# Altium schematic sheet Sensor-Sheet1.SchDoc(Sensor-Sheet1)
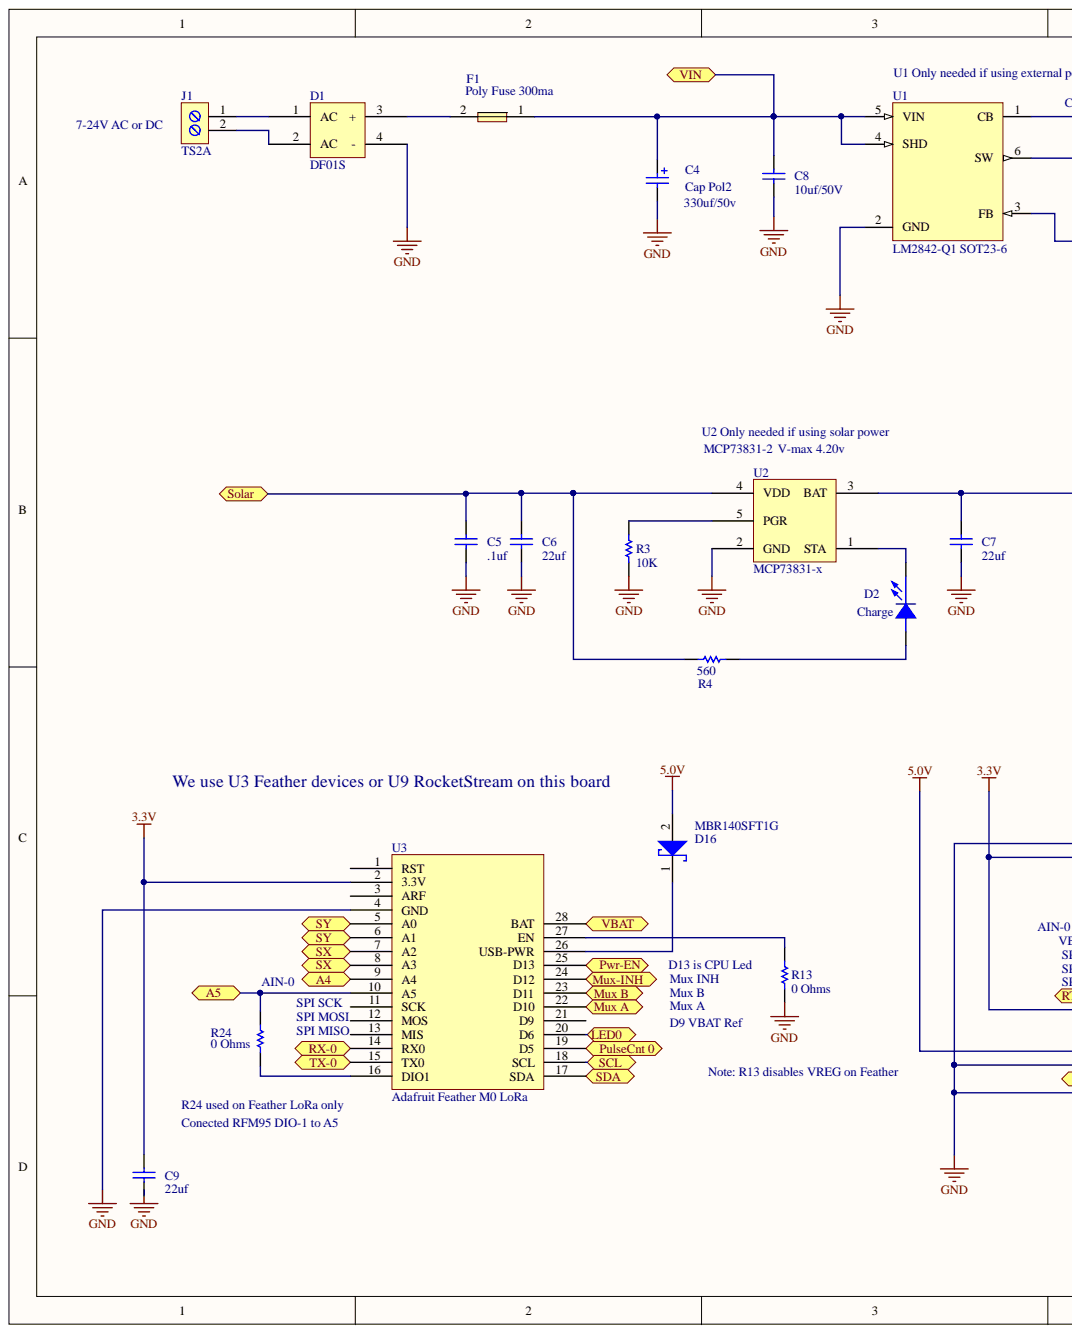
[diagram p0: Sensor-Sheet1.SchDoc, left]
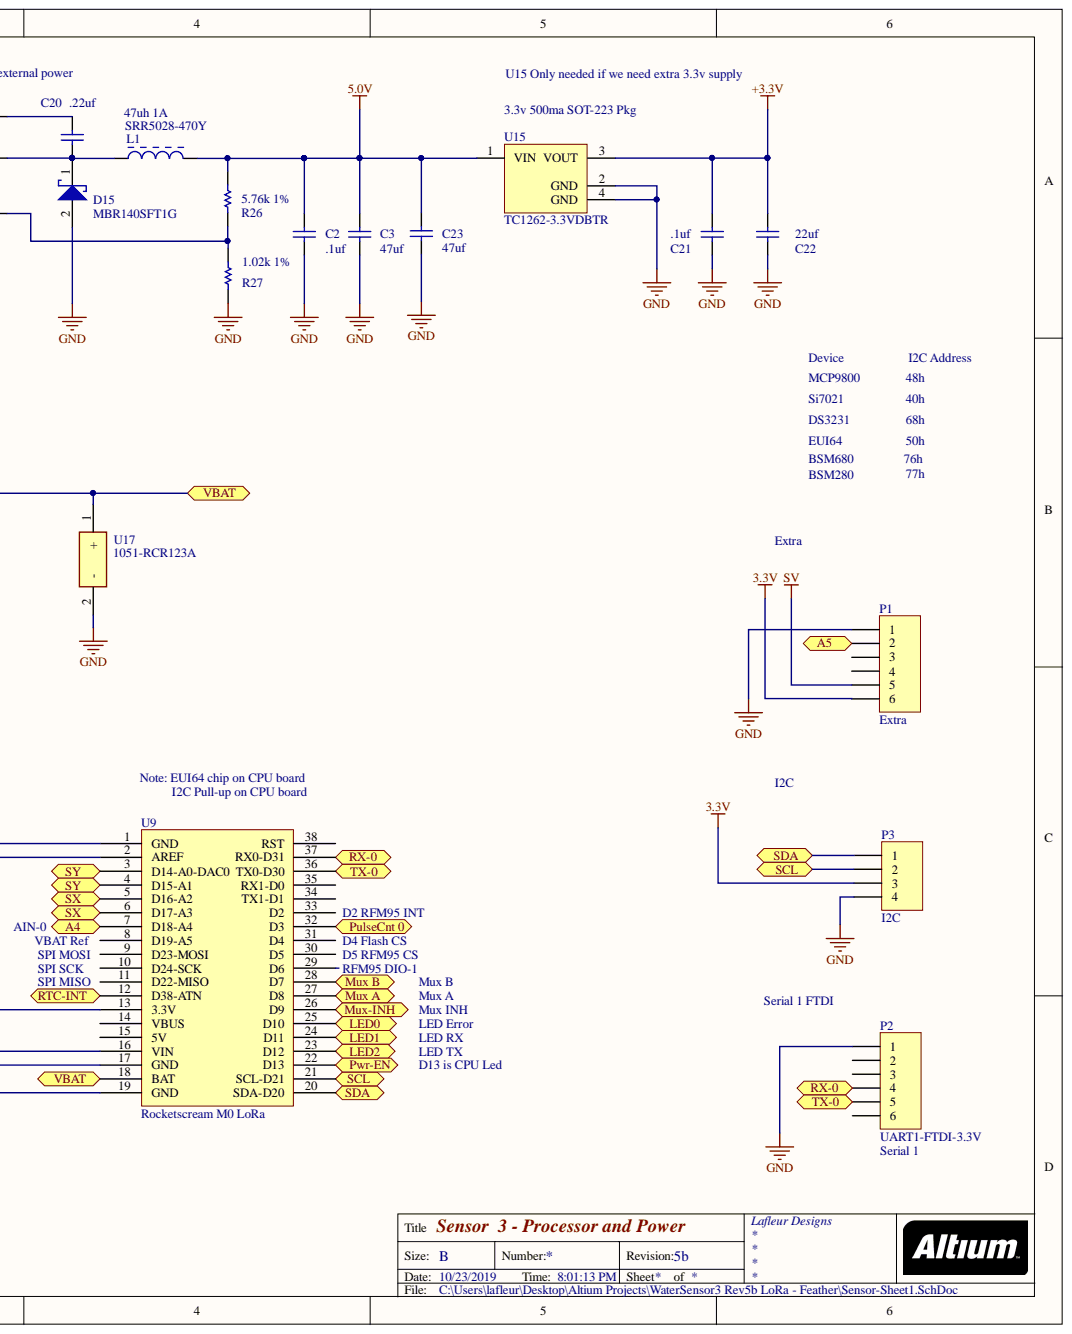
[diagram p1: Sensor-Sheet1.SchDoc, right]

## Parts
C2  .1uf  [6-0805]
C3  47uf  [C1210]
C4  Cap Pol2  value 330uf/50v  [Capacitor 12x5x18]
C5  .1uf  [0805]
C6  22uf  [C1206]
C7  22uf  [C1206]
C8  10uf/50V  [C1206]
C9  22uf  [C1206]
C20  .22uf  [6-0805]
C21  .1uf  [6-0805]
C22  22uf  [0805]
C23  47uf  [C1210]
D1  DF01S  [SOP4-Bridge]
D2  Charge  [LED 0805]
D15  MBR140SFT1G  [SOD123FL-2]
D16  MBR140SFT1G  [SOD123FL-2]
F1  Poly Fuse 300ma  [PTC 2016L]
J1  TS2A  [TS3.81X2]
L1  SRR5028-470Y  [BOUR-SRR5028_V -Mod]
P1  Extra  [HDR1X6]
P2  UART1-FTDI-3.3V  [HDR1X6]
P3  I2C  [HDR1X4]
R3  10K  [6-0805]
R4  560  [6-0805]
R13  0 Ohms  [6-0805]
R24  0 Ohms  [6-0805]
R26  5.76k 1%  [6-0805]
R27  1.02k 1%  [6-0805]
U1  LM2842-Q1 SOT23-6  [sot23-6]
U2  MCP73831-x  [SOT-23-OT5_L]
U3  Adafruit Feather M0 LoRa  [Feather-DIP28-800mil - duplicate]
U9  Rocketscream M0 LoRa  [Rocket-DIP38-900mil]
U15  TC1262-3.3VDBTR  [SOT-223-DB3_N]
U17  1051-RCR123A  [1051]

## Ports
A4, A5, LED0, LED1, LED2, Mux A, Mux B, Mux-INH, PulseCnt 0, Pwr-EN, RTC-INT, RX-0, SCL, SDA, Solar, SX, SY, TX-0, VBAT, VIN

## Nets
5.0V: C2.1 C3.1 C23.1 D16.2 L1.2 R26.1 U9.16(VIN) U15.1(VIN)
+3.3V: C9.1 C21.1 C22.1 P1.6 P3.3 U3.2(3.3V) U9.2(AREF) U9.13(3.3V) U15.3(VOUT)
GND: C2.2 C3.2 C4.1 C5.2 C6.2 C7.2 C8.2 C9.2 C21.2 C22.2 C23.2 D1.4(-) D15.2 P1.1 P2.1 P3.4 R3.1 R13.1 R27.2 U1.2(GND) U2.2(GND) U3.4(GND) U9.1(GND) U9.17(GND) U9.19(GND) U15.2(GND) U15.4(GND) U17.2
SV: P1.5
A4: U3.9(A4) U9.7(D18-A4) ; port A4
A5: P1.2 R24.1 U3.10(A5) ; port A5
LED0: U3.20(D6) U9.25(D10) ; port LED0
LED1: U9.24(D11) ; port LED1
LED2: U9.23(D12) ; port LED2
Mux A: U3.22(D10) U9.27(D8) ; port Mux A
Mux B: U3.23(D11) U9.28(D7) ; port Mux B
Mux-INH: U3.24(D12) U9.26(D9) ; port Mux-INH
PulseCnt 0: U3.19(D5) U9.32(D3) ; port PulseCnt 0
Pwr-EN: U3.25(D13) U9.22(D13) ; port Pwr-EN
RTC-INT: U9.12(D38-ATN) ; port RTC-INT
RX-0: P2.4 U3.14(RX0) U9.37(RX0-D31) ; port RX-0
SCL: P3.2 U3.18(SCL) U9.21(SCL-D21) ; port SCL
SDA: P3.1 U3.17(SDA) U9.20(SDA-D20) ; port SDA
Solar: C5.1 C6.1 R4.2 U2.4(VDD) ; port Solar
SX: U3.7(A2) U3.8(A3) U9.5(D16-A2) U9.6(D17-A3) ; port SX
SY: U3.5(A0) U3.6(A1) U9.3(D14-A0-DAC0) U9.4(D15-A1) ; port SY
TX-0: P2.5 U3.15(TX0) U9.36(TX0-D30) ; port TX-0
VBAT: C7.1 U2.3(BAT) U3.28(BAT) U9.18(BAT) U17.1 ; port VBAT
VIN: C4.2 C8.1 F1.1 U1.4(SHD) U1.5(VIN) ; port VIN
NetC20_1: C20.1 U1.1(CB)
NetC20_2: C20.2 D15.1 L1.1 U1.6(SW)
NetD1_1: D1.1(AC) J1.1
NetD1_2: D1.2(AC) J1.2
NetD1_3: D1.3(+) F1.2
NetD2_1: D2.1 R4.1
NetD2_2: D2.2 U2.1(STA)
NetD16_1: D16.1 U3.26(USB-PWR)
NetP1_3: P1.3
NetP1_4: P1.4
NetP2_2: P2.2
NetP2_3: P2.3
NetP2_6: P2.6
NetR3_2: R3.2 U2.5(PGR)
NetR13_2: R13.2 U3.27(EN)
NetR24_2: R24.2 U3.16(DIO1)
NetR26_2: R26.2 R27.1 U1.3(FB)
NetU3_1: U3.1(RST)
NetU3_3: U3.3(ARF)
NetU3_11: U3.11(SCK)
NetU3_12: U3.12(MOS)
NetU3_13: U3.13(MIS)
NetU3_21: U3.21(D9)
NetU9_8: U9.8(D19-A5)
NetU9_9: U9.9(D23-MOSI)
NetU9_10: U9.10(D24-SCK)
NetU9_11: U9.11(D22-MISO)
NetU9_14: U9.14(VBUS)
NetU9_15: U9.15(5V)
NetU9_29: U9.29(D6)
NetU9_30: U9.30(D5)
NetU9_31: U9.31(D4)
NetU9_33: U9.33(D2)
NetU9_34: U9.34(TX1-D1)
NetU9_35: U9.35(RX1-D0)
NetU9_38: U9.38(RST)

## Library data of the parts
C2 C3 C5-C9 C20 C23 = CAP_1: Capacitor; Fitted: True; Library Name: N2K Project.SCHLIB; Component Kind: Standard
C4 = Cap Pol2: Polarized Capacitor (Axial); Simulation: CAP; Published: 8-Jun-2000; PackageReference: POLAR0.8; PackageDescription: Polarized Capacitor; 2 Leads; Library Name: Miscellaneous Devices.IntLib; LatestRevisionNote: Re-released for DXP Platform.; LatestRevisionDate: 17-Jul-2002; Component Kind: Standard; Publisher: Altium Limited
C21 C22 = CAP_2: Capacitor; Fitted: True; Component Kind: Standard; Library Name: Winmor-Demo-R2.IntLib
D1 = Bridge DF01S: Library Name: WaterSensor.SCHLIB; Component Kind: Standard
D2 = LTST150: Vendor Part Number: LTST-C150GKRT; Part Description: SMT LED Green; Vendor: LITEON; Flex_PN: D20000; DEVICE: C150; Component Kind: Standard; Library Name: Winmor-Demo-R1.IntLib
D15 D16 = Diode Schottky: Schottky Diode; Published: 5-Jan-2004; DatasheetDocument: RevB, 21-Aug-2003; Component Kind: Standard; Publisher: Altium Limited; Library Name: Winmor-Demo-R2.IntLib
F1 = Poly Fuse: Library Name: N2K Project.SCHLIB; Description: Poly Fuse; Component Kind: Standard
J1 = TS2A: Screw Terminal, 3.81mm (.15in) 2X; Library Name: WaterSensor_1.SCHLIB; Component Kind: Standard
L1 = SRR5028-470Y: SDR0403 Series Power Inductor, 18 uF +/- 20 %, 0.34 Ohm, -40 to 125 degC, 2-Pin SMD, RoHS, Tape and Reel; PartNumber: SDR0403-180ML; Manufacturer: Bourns; Tolerance (%): +/- 20; Test Frequency (MHz): 2.52; SRF (MHz): 23 Min.; RDC (Ohm): 0.34 Max.; Q: 25; I sat (A): 1.3 Typ.; I rms (A): 0.84 Max.; Inductance (uH): 18; Temperature Range: -40 to 125 degC; RoHS: TRUE; Packing: Tape and Reel; PackageReference: SDR0403-2; PackageDescription: 3-Pin SMD, Dia 4.5 mm, Height 3.5 mm; Mounting Technology: Surface Mount; Manufacturer Part Number: SDR0403-180ML; Library Name: WaterSensor V3-5_1.SCHLIB; DatasheetVersion: Feb-12; ComponentLink2URL: http://www.bourns.com/data/global/pdfs/sdr0403.pdf; ComponentLink2Description: Datasheet; ComponentLink1URL: http://www.bourns.com/; ComponentLink1Description: Manufacturer URL; Component Kind: Standard
P1 P2 = Header 6: Header, 6-Pin; LatestRevisionNote: Re-released for DXP Platform.; LatestRevisionDate: 17-Jul-2002; Component Kind: Standard; Publisher: Altium Limited; Library Name: BG-Barometric-Sensor Rev5 802.SCHLIB
P3 = Header 4: Header, 4-Pin; Library Name: Miscellaneous Connectors.IntLib; LatestRevisionNote: Re-released for DXP Platform.; LatestRevisionDate: 17-Jul-2002; Component Kind: Standard; Publisher: Altium Limited
R3 R4 R13 R24 R26 R27 = ERJ8EN: Precision Thick Film Chip Resistor, 10 Ohm to 2.2M Ohm Range, 1% Tolerance, 1206 Size, 0.125 W; Simulation: RESISTOR; Published: 17-Dec-2003; PackageReference: 8-1206; PackageDocument: Mar-2003; LatestRevisionNote: Silkscreen size reduced.; LatestRevisionDate: 19-Mar-2004; ComponentLink2URL: http://www.panasonic.com/industrial/components/pdf/AOA0000CE2.pdf; ComponentLink2Description: Datasheet; ComponentLink1URL: http://www.panasonic.com/industrial/components/resistive_products.htm; ComponentLink1Description: Manufacturer Link; Component Kind: Standard; Code_IPC: 3216 [1206]; Publisher: Altium Limited
  R3 R4 R13 R24: Library Name: N2K Project.SCHLIB
  R26 R27: Library Name: Panasonic Resistor.IntLib
U1 = LM2842: .6A SIMPLE SWITCHER® Step-Down Voltage Regulator SOT23-6; Manufacturer: National Semiconductor; PowerWise: N; PackageTopMarking: NSXYTT*L22670*5.0; RoHS: Y; RevisionNote: DatasheetVersion Updated. Component URLs added; PinCount: 8; PackageVersion: revB, Dec-2007; PackageReference: MRA08B; PackageDescription: Molded, PSOP .193 x .154 x .058in, 8 Lead, .050in Pitch, Large DAP; Library Name: WaterSensor 2_7.SCHLIB; DatasheetVersion: Jan-2011; CompType: Switcher; ComponentLink3URL: http://www.national.com/packaging/folders/mra08b.html; ComponentLink3Description: MRA08B Package Page; ComponentLink2URL: http://www.national.com/pf/LM/LM22670.html; ComponentLink2Description: LM22670 Web Page; ComponentLink1URL: http://www.national.com/ds/LM/LM22670.pdf; ComponentLink1Description: LM22670 Datasheet; Component Kind: Standard; Code_JEDEC: MS-012-AA
U2 = MCP7381: MCP73831 Li-Ion, Li-Polymer Charger; Library Name: WaterSensor_5.SCHLIB; Component Kind: Standard
U3 = Feather M0 LoRa: Library Name: WaterSensor 2_6.SCHLIB; Description: Feather M0 LoRa; Component Kind: Standard
U9 = Rocket M0 LoRa: Library Name: WaterSensor 2_1.SCHLIB; Description: Rocket M0 LoRa; Component Kind: Standard
U15 = TC1262-3.3VDBTR: 500mA Fixed Output CMOS LDO, 3-Pin SOT-223, Extended Temperature, Tape and Reel; Supplier Part Number 4: 1296594; Supplier Part Number 3: TC1262-3.3VDBDKR-ND; Supplier Part Number 2: TC1262-3.3VDBCT-ND; Supplier Part Number 1: TC1262-3.3VDBTR-ND; Supplier 4: Farnell; Supplier 3: Digi-Key; Supplier 2: Digi-Key; Supplier 1: Digi-Key; Published: 29-Jan-2009; PackageVersion: revBB, Aug-2009; PackageReference: SOT-223-DB3; PackageDescription: 3-Lead Plastic Small Outline Transistor (DB) [SOT-223]; DatasheetVersion: revB, 2002; ComponentLink3URL: http://www.microchip.com/; ComponentLink3Description: Microchip.com Home; ComponentLink2URL: http://www.microchip.com/stellent/groups/techpub_sg/documents/packagingspec/en012702.pdf; ComponentLink2Description: Packaging Specification; ComponentLink1URL: http://ww1.microchip.com/downloads/en/DeviceDoc/21373b.pdf; ComponentLink1Description: Datasheet; Component Kind: Standard; Publisher: Altium Limited; Library Name: Winmor-Demo-R2.IntLib
U17 = 1051: Undefined or Miscellaneous; Manufacturer_Name: Keystone Electronics; Allied_Number: 71135178; Mouser Price/Stock: https://www.mouser.com/Search/Refine.aspx?Keyword=534-1051; Mouser Part Number: 534-1051; Manufacturer_Part_Number: 1051; Height: 15.24mm; Datasheet Link: https://www.keyelco.com/product-pdf.cfm?p=5205; Component Kind: Standard; Allied Price/Stock: https://www.alliedelec.com/keystoneelectronics-1051/71135178/
